# Altium schematic sheet [15]-CLOCK.SchDoc([15]-CLOCK)
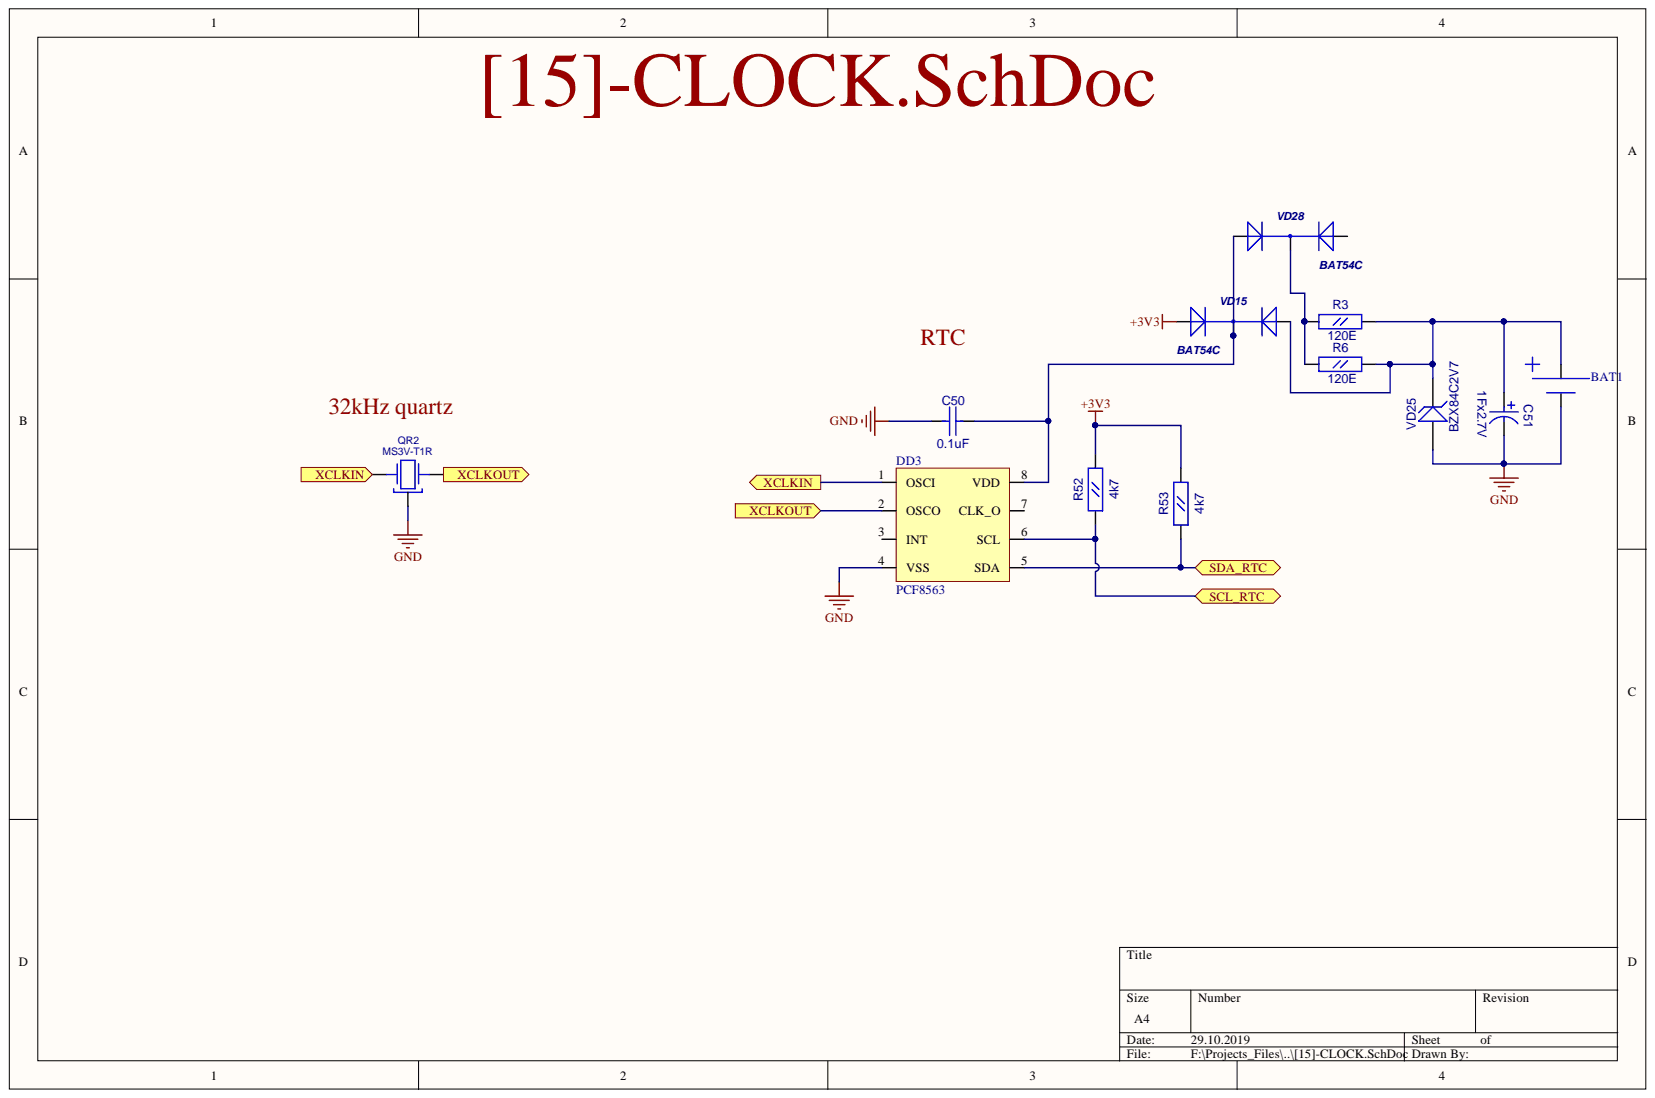
[diagram p0: [15]-CLOCK.SchDoc]

## Parts
BAT1  CR2032-VBY4  [CR2032_ONBOARD]  (value not drawn)
C50  0.1uF  [CC0603]
C51  1Fx2.7V  [CC8X11.5_L]
DD3  PCF8563  [SO-8]
QR2  MS3V-T1R  [MS3V-T1R]
R3  120E  [RC0805]
R6  120E  [RC0805]
R52  4k7  [RC0603]
R53  4k7  [RC0603]
VD15  BAT54C  [SOT-23(123)]
VD25  BZX84C2V7  [SOT-23(123)]
VD28  BAT54C  [SOT-23(123)]

## Ports
SCL_RTC, SDA_RTC, XCLKIN, XCLKOUT

## Nets
+3V3: R52.2 R53.2 VD15.1
GND: BAT1.1 C50.1 C51.2 DD3.4(VSS) QR2.3 VD25.1
SCL_RTC: DD3.6(SCL) R52.1 ; port SCL_RTC
SDA_RTC: DD3.5(SDA) R53.1 ; port SDA_RTC
XCLKIN: DD3.1(OSCI) QR2.2 ; port XCLKIN
XCLKOUT: DD3.2(OSCO) QR2.1 ; port XCLKOUT
NetBAT1_2: BAT1.2 C51.1 R3.2 R6.2 VD15.2 VD25.3
NetC50_2: C50.2 DD3.8(VDD) VD15.3 VD28.1
NetR3_1: R3.1 R6.1 VD28.3

Not connected: DD3 3(INT) 7(CLK_O); VD28 2

## Library data of the parts
BAT1 = CR2032-VBY2: Value: CR2032-VBY4; Component Kind: Standard; Library Name: fizik_lib.SchLib
C50 = CC0603: Value: 0.1uF; Description: CC0603; Component Kind: Standard; Library Name: gprs_modem_2.SchLib
C51 = CAP_8mm: Radial capacitor; Value: 1Fx2.7V; Component Kind: Standard; Library Name: fizik_lib.SchLib
QR2 = MS3V-T1R: CRYSTAL_32768 Hz; Value: MS3V-T1R; Component Kind: Standard; Library Name: gprs_modem.SchLib
R3 R6 = RC0805: Value: 120E; Description: RC0805; Component Kind: Standard; Library Name: fizik_lib.SchLib
R52 R53 = RC0603: Value: 4k7; Description: RC0603; Component Kind: Standard; Library Name: gprs_modem_2.SchLib
VD25 = BZX84: Zener Voltage Regulator Diode; Value: BZX84C2V7; Component Kind: Standard; Library Name: fizik_lib.SchLib
DD3 = PCF8563: Value: PCF8563; Component Kind: Standard; Library Name: gprs_modem.SchLib
VD15 VD28 = BAT54C: Description: BAT54C; Component Kind: Standard; Library Name: fizik_lib.SchLib
